# Altium schematic sheet 01-FBD.SchDoc
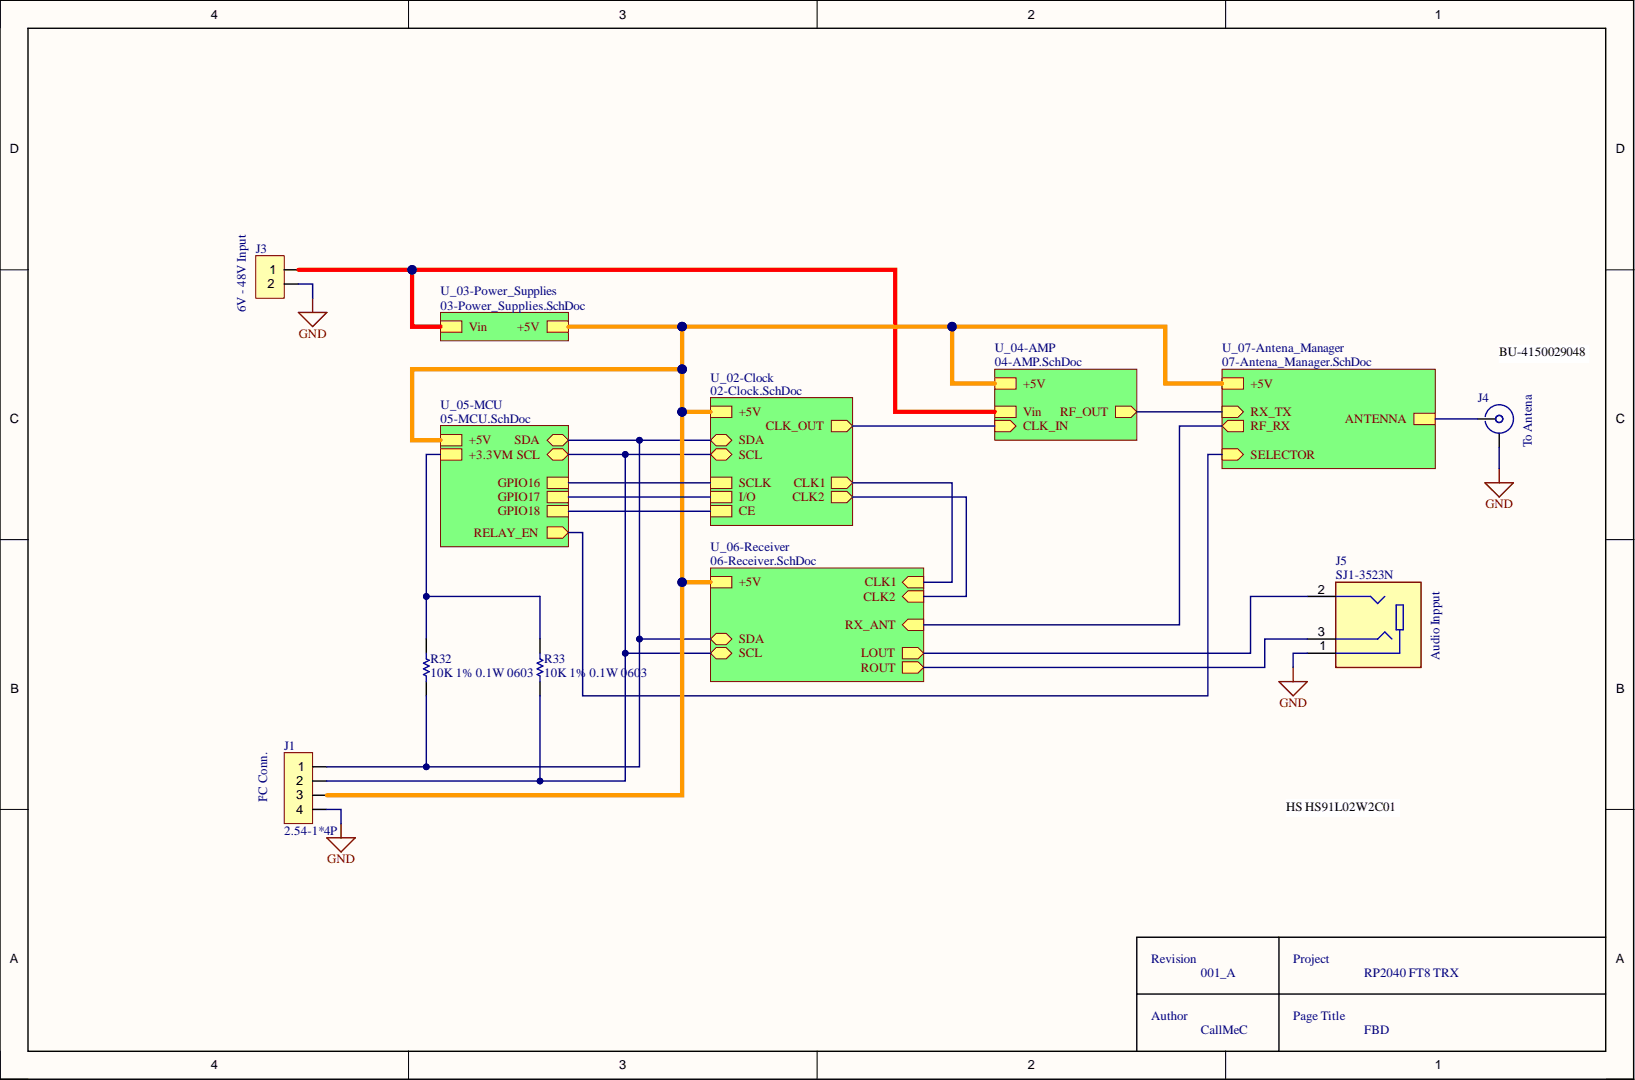
[diagram p0: 01-FBD.SchDoc]

## Parts
J1  2.54-1*4P  [FP-87224-3-MFG]
J3  MX635-6.35-02P-GN01-Cu-PS-A  [MX635-6.35-02P-GN01-Cu-PS-A-Footprint-1]  (value not drawn)
J4  BWSMA-KE-P001  [TE_CONSMA020.062-G]  (value not drawn)
J5  SJ1-3523N  [CUI_SJ1-3523N]
R32  10K 1% 0.1W 0603  value 10K  [R0603]
R33  10K 1% 0.1W 0603  value 10K  [R0603]

## Nets
GND: J1.4 J3.2 J4.G J5.1
NetJ1_1: J1.1 R32.1
NetJ1_2: J1.2 R33.1
NetJ1_3: J1.3
NetJ3_1: J3.1
NetJ4_1: J4.1
NetJ5_2: J5.2
NetJ5_3: J5.3
NetR32_2: R32.2 R33.2
CE:
CLK1:
CLK2:
CLK_IN:
GPIO16:
GPIO17:
RELAY_EN:
RF_OUT:
RF_RX:

## Library data of the parts
J1 = CMP-002-00121-1: 2.54mm Single Row 3A 4P Bronze -40℃~+105℃ Direct Insert 1x4P Top Square Hole Plugin,P=2.54mm Female Headers ROHS; MFR: BOOMELE(Boom Precision Elec); Component Kind: Standard; LCSC_Part_#: C2718488; LCSC_Price_1ku: 0.026; MFR_Part_#: 2.54-1*4P; Library Name: SAS France Réducteurs
J3 = CMP-002-00092-2: Copper Alloy 1x2P Tin 6.35mm 4 1 2 -40℃~+105℃ 30A 300V Green 10~26 Straight Plugin,P=6.35mm Screw terminal ROHS; Component Kind: Standard; LCSC_Part_#: C5188489; LCSC_Price_1ku: 0.1045; MFR_Part_#: MX635-6.35-02P-GN01-Cu-PS-A; Library Name: SAS France Réducteurs
J4 = CMP-543-000000-2: 1 Inner Hole SMA Board-End Staggered pins 50Ω 5.2mm SMD Coaxial Connectors (RF) ROHS; MFR: BAT WIRELESS; Component Kind: Standard; LCSC_Part_#: C496550; LCSC_Price_1lu: 0.2065; MFR_Part_#: BWSMA-KE-P001; Library Name: SAS France Réducteurs
J5 = CMP-545-000000-2: 3.5 mm, Stereo, Right Angle, Through Hole, 3 Conductors, 0 Internal Switches, Audio Jack Connector; MFR: CUI; Component Kind: Standard; LCSC_Part_#: C20182914; LCSC_Price_1ku: 0.6476; MFR_Part_#: SJ1-3523N; Library Name: SAS France Réducteurs
R32 R33 = CMP-356-000043-3: Standard Resistor 10K 1% 0.1W 0603; Manufacturer: Generic; MFR_Part_#: 0603WAF1002T5E; TC: 200; Component Kind: Standard; Component Type: Standard; Design Item ID: 10K 1% 0.1W 0603; LCSC_Part_#: C25804; LCSC_Price_1ku: 0.0007; Library Name: FT8.SCHLIB; PartNumber: 10K 1% 0.1W 0603; Pin Count: 2; Power: 0.1W; Tolerance: 1%; Source: GT_WH_Control.SCHLIB
